# Altium schematic sheet 2_IsoCAN.SchDoc
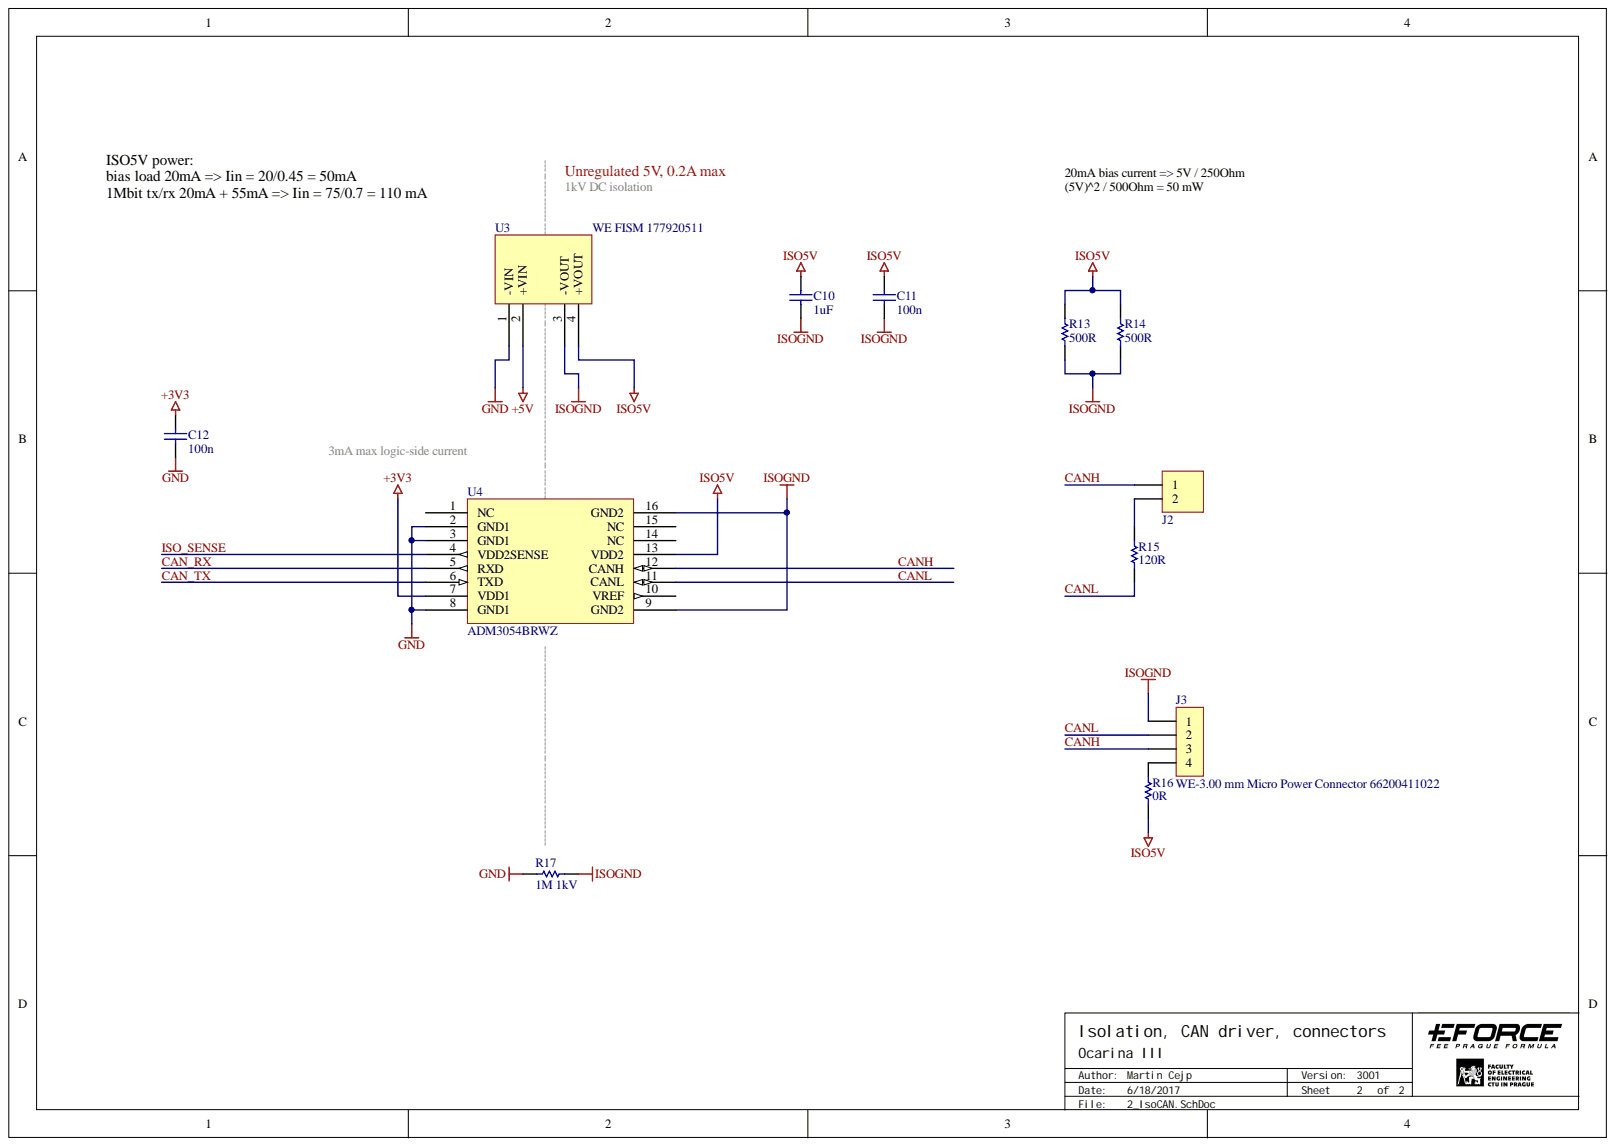
[diagram p0: 2_IsoCAN.SchDoc]

## Parts
C10  1uF  [CAPC0805(2012)145_M]
C11  100n  [CAPC0603(1608)100_M]
C12  100n  [CAPC0603(1608)100_M]
J2  02p  [61300211121]  (value not drawn)
J3  WE-3.00 mm Micro Power Connector 66200411022  [66200411022]
R13  500R  [RESC0603(1608)_L]
R14  500R  [RESC0603(1608)_L]
R15  120R  [RESC0603(1608)_L]
R16  0R  [RESC0603(1608)_L]
R17  1M 1kV  [RESC1206(3216)_L]
U3  WE FISM 177920511  [FISM_17792XXX1]
U4  ADM3054BRWZ  [SOIC127P1030X265-16N]

## Nets
+3V3: C12.2 U4.7(VDD1)
+5V: U3.2(+VIN)
GND: C12.1 R17.1 U3.1(-VIN) U4.2(GND1) U4.3(GND1) U4.8(GND1)
ISO5V: C10.2 C11.2 R13.2 R14.2 R16.1 U3.4(+VOUT) U4.13(VDD2)
ISOGND: C10.1 C11.1 J3.1 R13.1 R14.1 R17.2 U3.3(-VOUT) U4.9(GND2) U4.16(GND2)
CAN_RX: U4.5(RXD)
CAN_TX: U4.6(TXD)
CANH: J2.1 J3.3 U4.12(CANH)
CANL: J3.2 R15.1 U4.11(CANL)
ISO_SENSE: U4.4(VDD2SENSE)
NetJ2_2: J2.2 R15.2
NetJ3_4: J3.4 R16.2

Not connected: U4 1(NC) 10(VREF) 14(NC) 15(NC)

## Library data of the parts
C10 = CMP-1036-04748-1: CAP 1uF 16V ±20% 0805 (2012 Metric) Thickness 1.45mm SMD; Case-EIA: 0805; Case-Metric: 2012; Tolerance: Â±20%; Component Kind: Standard; Library Name: Altium Content Vault; Max Thickness: 1.45 mm; Rated Voltage: 16 V; Technology: SMT; Value: 1uF
C11 C12 = CMP-1035-02576-1: CAP 100nF 6.3V ±10% 0603 (1608 Metric) Thickness 1mm SMD; Case-EIA: 0603; Case-Metric: 1608; Tolerance: Â±10%; Component Kind: Standard; Library Name: Altium Content Vault; Max Thickness: 1 mm; Rated Voltage: 6.3 V; Technology: SMT; Value: 100nF
J2 = 61300211121: WR-PHD 2.54 mm THT Pin Header, 02p; Component Kind: Standard; ComponentLink1Description: Manufacturer; ComponentLink1URL: http://www.we-online.de; ComponentLink2Description: Datasheet; ComponentLink2URL: http://katalog.we-online.de/em/datasheet/6130xx11121.pdf; Library Name: ALTIUM-PHD_2_54_THT_PIN_HEADER_6130XX11121-rev16c.IntLib; PartNumber: 61300211121; PinNumber: 02p; PublishedDate: July 2016; Publisher: Wurth Elektronik
J3 = WE-3.00 mm Micro Power Connector 66200411022: WR-MPC3 3.00 mm Male Single Row Angled Header; Manufacturer: W¨ąrth Elektronik eiSos GmbH; ComponentLink1 Description: Manufacturer; ComponentLink2 Description: Datasheet; NoPins: 04; Component Kind: Standard; ComponentLink1URL: http://www.we-online.com; ComponentLink2URL: http://katalog.we-online.de/em/datasheet/6620xx11022.pdf; Created Date: 28 August 2013; Library Name: ALTIUM-WR-MPC3_MALE_HEADER-rev16b.IntLib; partNo: 66200411022; Created by: Karrer Zheng
R13-R15 = CMP-1012-00052-1: 120R 0.1W 5% 0603 (1608 Metric)  SMD; Case-EIA: 0603; Case-Metric: 1608; Value: 120.00 Ohm; Component Kind: Standard; Library Name: Altium Content Vault; Power: 0.1 W; Technology: SMT; Tolerance: 5 %
R16 = CMP-1012-00052-1: 0R 0.1W 5% 0603 (1608 Metric)  SMD; Case-EIA: 0603; Case-Metric: 1608; Value: 0.00 Ohm; Component Kind: Standard; Library Name: Altium Content Vault; Power: 0.1 W; Technology: SMT; Tolerance: 5 %
R17 = CMP-1014-00146-1: 1M 0.25W 5% 1206 (3216 Metric)  SMD; Case-EIA: 1206; Case-Metric: 3216; Value: 1000000.00 Ohm; Component Kind: Standard; Library Name: Altium Content Vault; Power: 0.25 W; Technology: SMT; Tolerance: 5 %
U3 = 177920511: Component Kind: Standard; Description: WE FISM 177920511; Library Name: WE_FISM_177920511.SchLib
U4 = ADM3054BRWZ: Component Kind: Standard; Library Name: ADM3054BRWZ.SchLib
